# Altium schematic sheet 03 PX4-IMU-V1.0.SchDoc("03 PX4-IMU-V1.0")
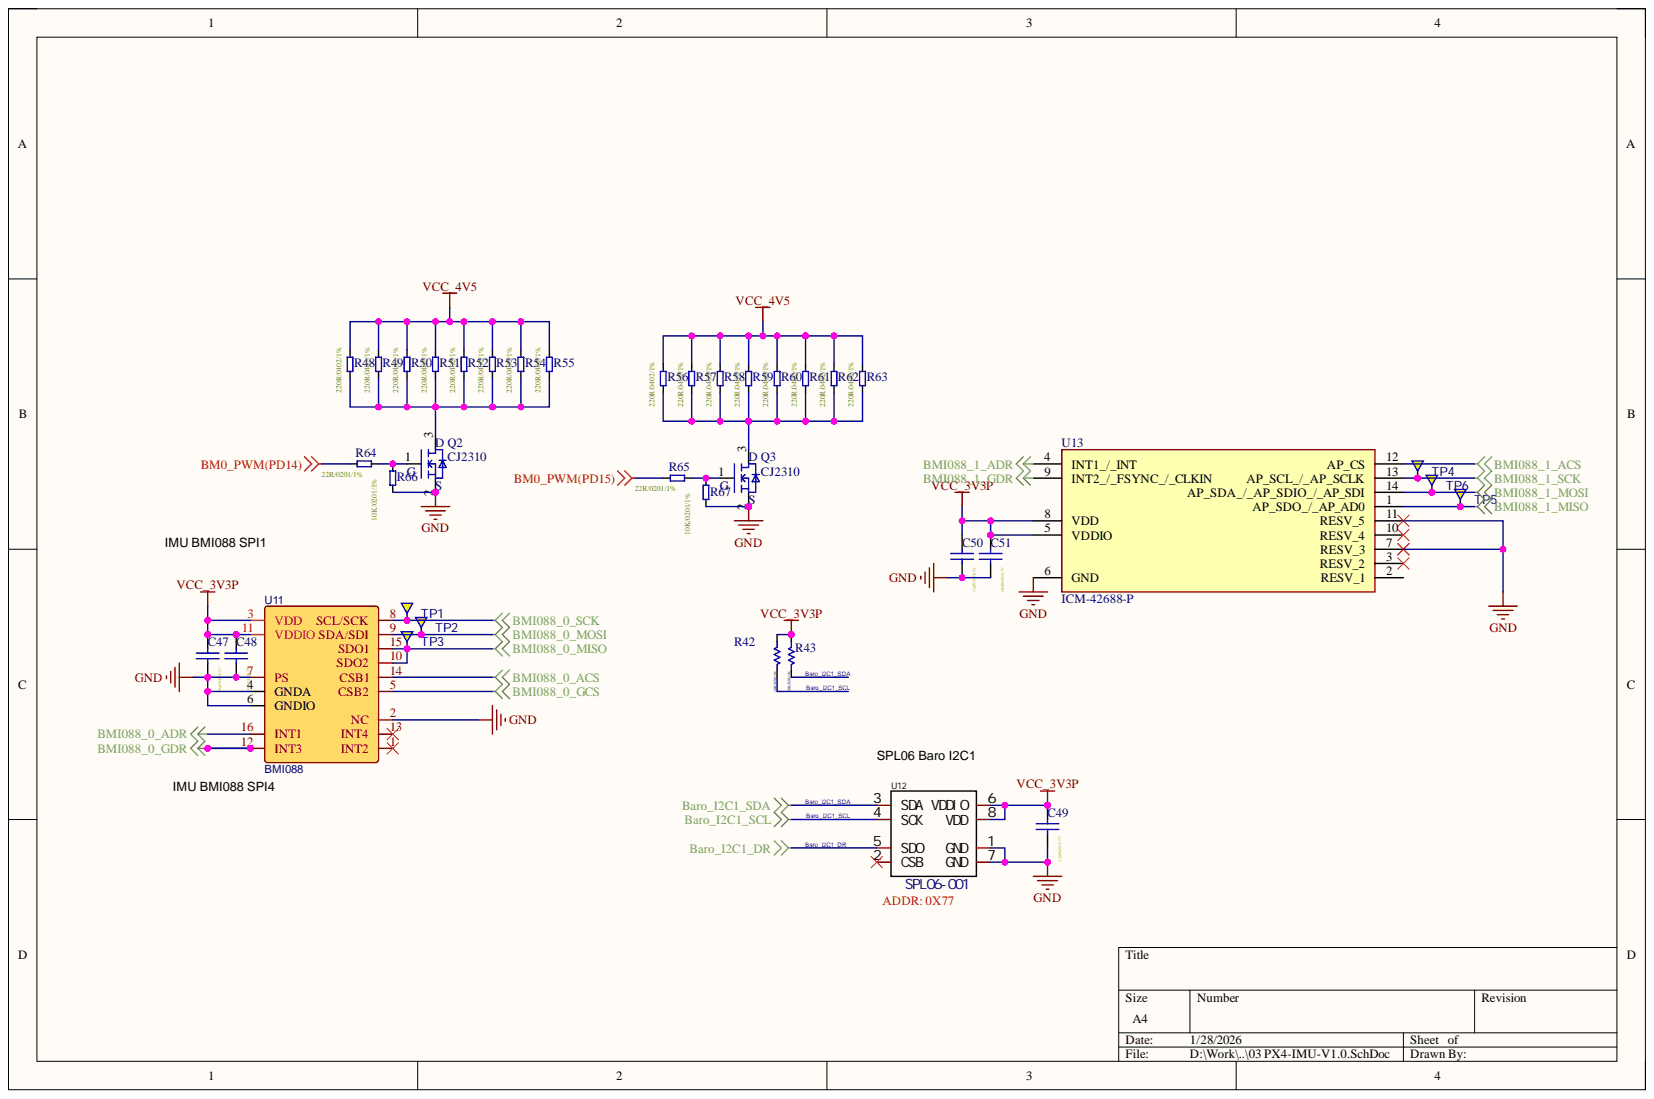
[diagram p0: 03 PX4-IMU-V1.0.SchDoc]

## Parts
C47  10uF/0402/6.3V  [C0402]
C48  10uF/0402/6.3V  [C0402]
C49  2.2uF/0201/6.3V  [C0201]
C50  10uF/0402/6.3V  [C0402]
C51  10uF/0402/6.3V  [C0402]
Q2  CJ2310  [SOT-23]
Q3  CJ2310  [SOT-23]
R42  10K/0201/1%  [R0201]
R43  10K/0201/1%  [R0201]
R48  220R/0402/1%  [R0402]
R49  220R/0402/1%  [R0402]
R50  220R/0402/1%  [R0402]
R51  220R/0402/1%  [R0402]
R52  220R/0402/1%  [R0402]
R53  220R/0402/1%  [R0402]
R54  220R/0402/1%  [R0402]
R55  220R/0402/1%  [R0402]
R56  220R/0402/1%  [R0402]
R57  220R/0402/1%  [R0402]
R58  220R/0402/1%  [R0402]
R59  220R/0402/1%  [R0402]
R60  220R/0402/1%  [R0402]
R61  220R/0402/1%  [R0402]
R62  220R/0402/1%  [R0402]
R63  220R/0402/1%  [R0402]
R64  22R/0201/1%  [R0201]
R65  22R/0201/1%  [R0201]
R66  10K/0201/1%  [R0201]
R67  10K/0201/1%  [R0201]
TP1  Testpad  [TP-0.6]  (value not drawn)  (no BOM)
TP2  Testpad  [TP-0.6]  (value not drawn)  (no BOM)
TP3  Testpad  [TP-0.6]  (value not drawn)  (no BOM)
TP4  Testpad  [TP-0.6]  (value not drawn)  (no BOM)
TP5  Testpad  [TP-0.6]  (value not drawn)  (no BOM)
TP6  Testpad  [TP-0.6]  (value not drawn)  (no BOM)
U11  BMI088  [LGA-16-L4.5-W3.0-P0.50_TL]
U12  SPL06-001  [SENSOR-SMD_SPL06-001]
U13  ICM-42688-P  [IIM42352]

## Nets
BM0_PWM(PD14): R64.2
BM0_PWM(PD15): R65.2
BMI088_0_ACS: U11.14(CSB1)
BMI088_0_ADR: U11.16(INT1)
BMI088_0_GCS: U11.5(CSB2)
BMI088_0_GDR: U11.12(INT3)
BMI088_0_MISO: TP3.1 U11.10(SDO2) U11.15(SDO1)
BMI088_0_MOSI: TP2.1 U11.9(SDA/SDI)
BMI088_0_SCK: TP1.1 U11.8(SCL/SCK)
BMI088_1_ACS: U13.12(AP_CS)
BMI088_1_ADR: U13.4(INT1_/_INT)
BMI088_1_GDR: U13.9(INT2_/_FSYNC_/_CLKIN)
BMI088_1_MISO: TP5.1 U13.1(AP_SDO_/_AP_AD0)
BMI088_1_MOSI: TP6.1 U13.14(AP_SDA_/_AP_SDIO_/_AP_SDI)
BMI088_1_SCK: TP4.1 U13.13(AP_SCL_/_AP_SCLK)
GND: C47.2 C48.2 C49.2 C50.2 C51.2 Q2.2 Q3.2 R66.2 R67.2 U11.2(NC) U11.4(GNDA) U11.6(GNDIO) U11.7(PS) U12.1(GND) U12.7(GND) U13.6(GND) U13.7(RESV_3) U13.11(RESV_5)
VCC_3V3P: C47.1 C48.1 C49.1 C50.1 C51.1 R42.1 R43.1 U11.3(VDD) U11.11(VDDIO) U12.6(VDDIO) U12.8(VDD) U13.5(VDDIO) U13.8(VDD)
VCC_4V5: R48.2 R49.2 R50.2 R51.2 R52.2 R53.2 R54.2 R55.2 R56.2 R57.2 R58.2 R59.2 R60.2 R61.2 R62.2 R63.2
Baro_I2C1_DR: U12.5(SDO)
Baro_I2C1_SCL: R42.2 U12.4(SCK)
Baro_I2C1_SDA: R43.2 U12.3(SDA)
NetQ2_1: Q2.1 R64.1 R66.1
NetQ2_3: Q2.3 R48.1 R49.1 R50.1 R51.1 R52.1 R53.1 R54.1 R55.1
NetQ3_1: Q3.1 R65.1 R67.1
NetQ3_3: Q3.3 R56.1 R57.1 R58.1 R59.1 R60.1 R61.1 R62.1 R63.1

Not connected: U11 1(INT2) 13(INT4); U12 2(CSB); U13 2(RESV_1) 3(RESV_2) 10(RESV_4)

## Library data of the parts
C47-C51 = Cap: Value: 100nF/0603/50V; Component Kind: Standard; LatestRevisionDate: 17-Jul-2002; LatestRevisionNote: Re-released for DXP Platform.; Library Name: Miscellaneous Devices.IntLib; PackageDescription: Radial Cap, Thru-Hole; 2 Leads; 0.3 in Pin Spacing; PackageReference: RAD-0.3; Published: 8-Jun-2000; Signal Integrity: Cap; Simulation: CAP; Publisher: Altium Limited
  C47 C48 C50 C51: Description: 10uF/0402/6.3V
  C49: Description: 2.2uF/0201/6.3V
Q2 Q3 = AO3400A: Component Kind: Standard; Description: CJ2310; Library Name: dm-sch_library.SchLib
R42 R43 = Res Semi: Component Kind: Standard; Description: 10K/0201/1%; LatestRevisionDate: 17-Jul-2002; LatestRevisionNote: Re-released for DXP Platform.; Library Name: Miscellaneous Devices.IntLib; PackageDescription: Axial Device, Thru-Hole; 2 Leads; 0.5 in Pin Spacing; PackageReference: AXIAL-0.5; Published: 8-Jun-2000; Publisher: Altium Limited
R48-R67 = R: Component Kind: Standard; Library Name: ROBO_V01_2015.SchLib
  R48-R63: Description: 220R/0402/1%
  R64 R65: Description: 22R/0201/1%
  R66 R67: Description: 10K/0201/1%
TP1-TP6 = Testpad: Testpad, round, 1.5mm; PartNumber: TP-R-1.5; Library Name: jetson-nano-baseboard.SCHLIB
U11 = BMI088: BOM_Manufacturer: Bosch Sensortec; Contributor: LCSC; SMT Type: Extend; BOM_JLCPCB SMD: Yes; BOM_Manufacturer Part: BMI088; BOM_Supplier: LCSC; BOM_Supplier Part: C194919; Component Kind: Standard; Library Name: dm-sch_library.SchLib; spicePre: U; spiceSymbolName: BMI088
U12 = SPL06-001: Manufacturer: Goertek(歌尔); Add into BOM: yes; Device: SPL06-001_C2684428; Component Kind: Standard; Convert to PCB: yes; Datasheet: https://atta.szlcsc.com/upload/public/pdf/source/20210120/C2684428_7EA58BBCA3793458F617124FBE4BF8F1.pdf; JLCPCB Part Class: Extended Part; LCSC Part Name: 普通气压传感器; Library Name: dm-sch_library.SchLib; Manufacturer Part: SPL06-001; Name: SPL06-001; PCB3D: SENSOR-SMD_SPL06-001; Supplier: LCSC; Supplier Footprint: SMD-8P,2x2.5mm; Supplier Part: C2684428; Symbol: SPL06-001
U13 = ICM-42688-P: IMU (Inertial Measurement Unit), 6-Axis, Accelerometer, Gyroscope; Manufacturer_Name: TDK; Arrow Part Number: ICM-42688-P; Arrow Price/Stock: https://www.arrow.com/en/products/icm-42688-p/invensense?region=nac; Component Kind: Standard; Datasheet Link: https://3cfeqx1hf82y3xcoull08ihx-wpengine.netdna-ssl.com/wp-content/uploads/2021/06/DS-000347-ICM-42688-P-v1.5.pdf; Library Name: dm-sch_library.SchLib; Manufacturer_Part_Number: ICM-42688-P; Mouser Part Number: 410-ICM-42688-P; Mouser Price/Stock: https://www.mouser.co.uk/ProductDetail/TDK-InvenSense/ICM-42688-P?qs=OlC7AqGiEDk8QrNAV728zw%3D%3D
